# Altium schematic sheet Main Loom Schematic.SchDoc
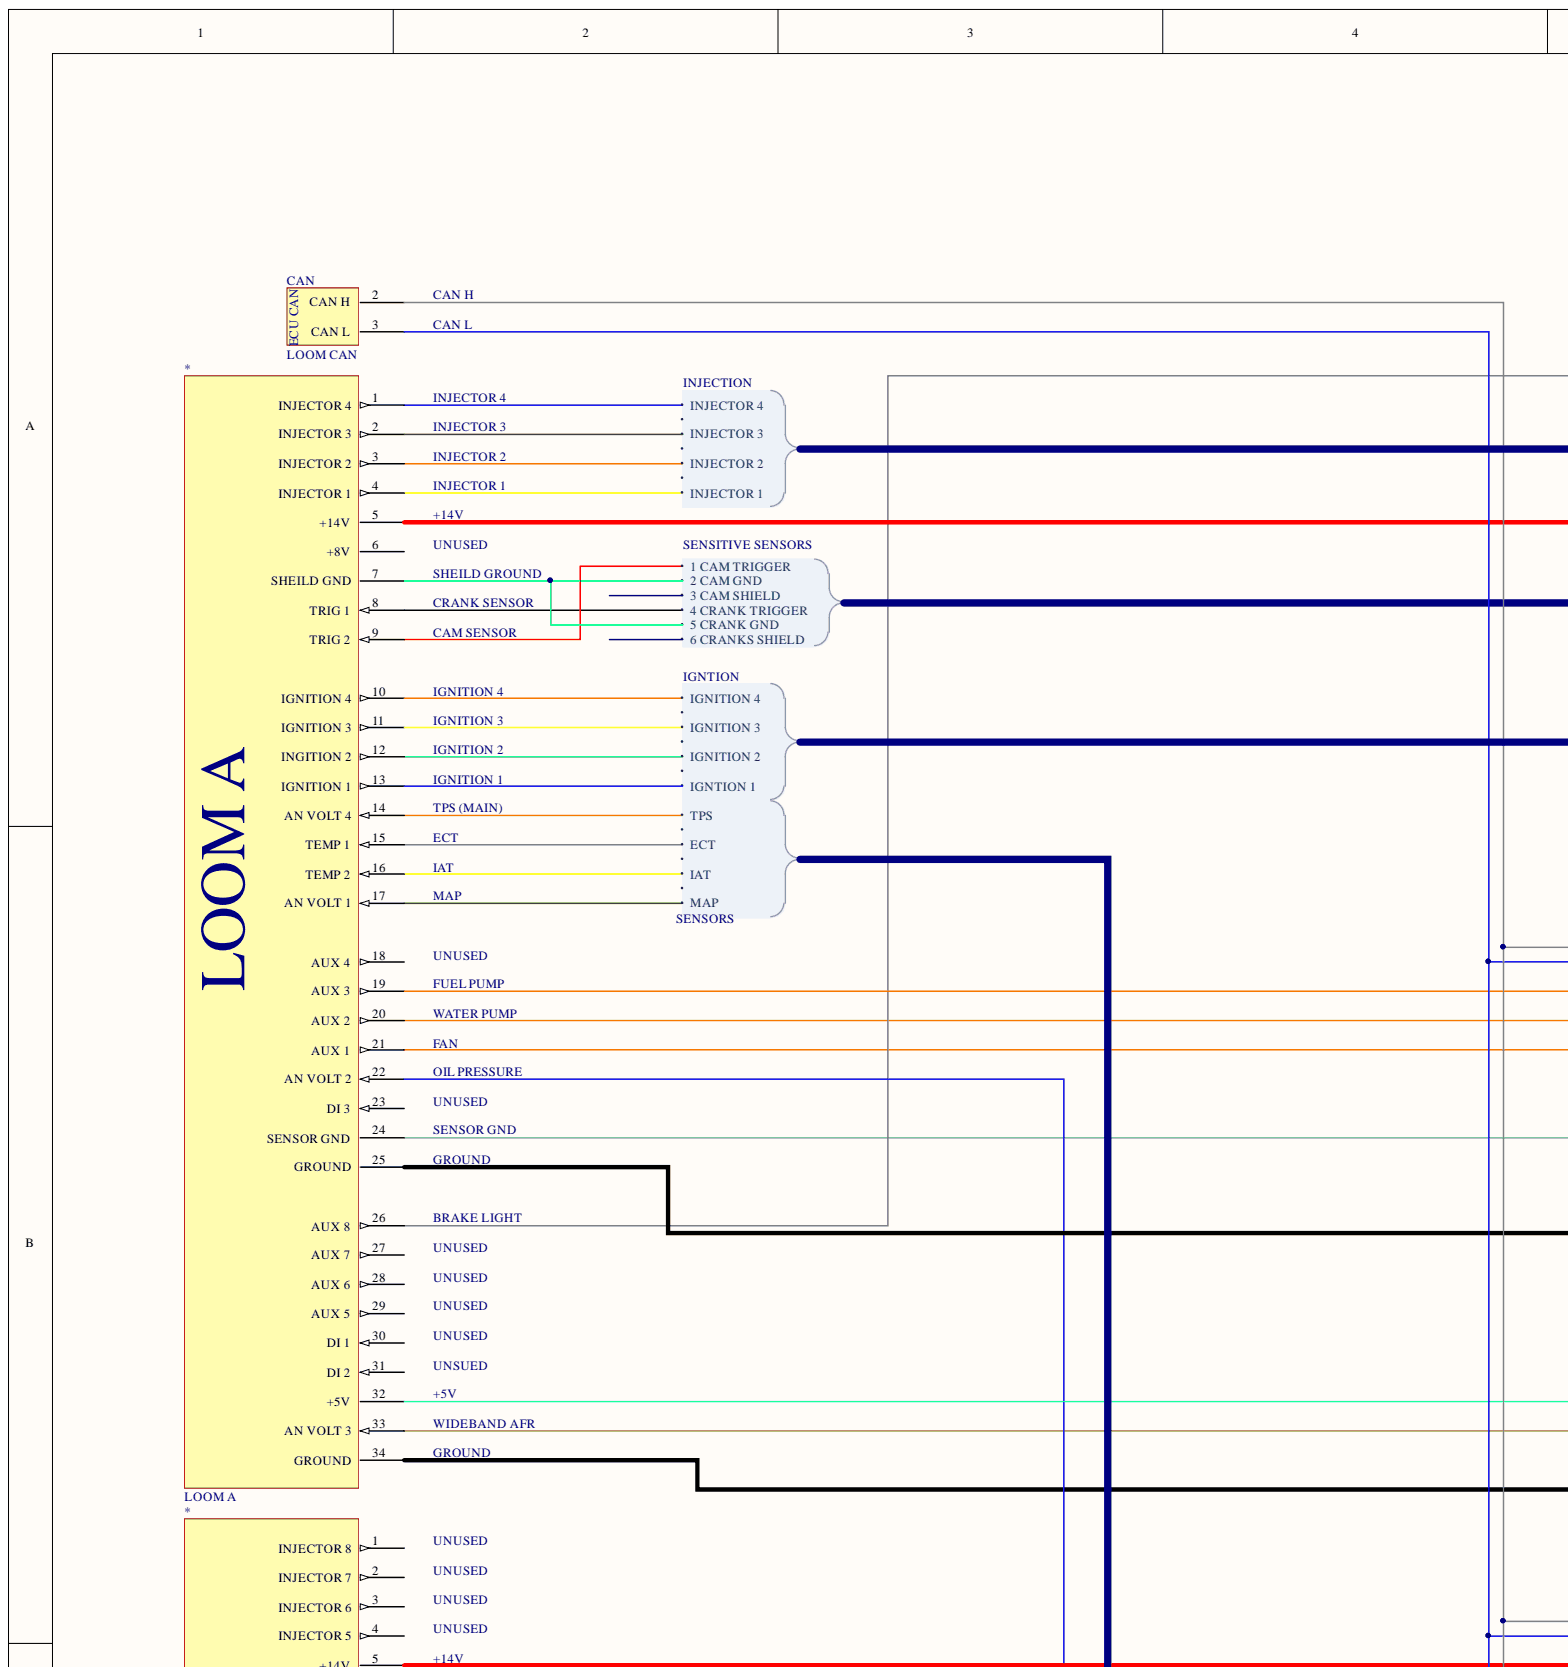
[diagram p0: Main Loom Schematic.SchDoc, top left]
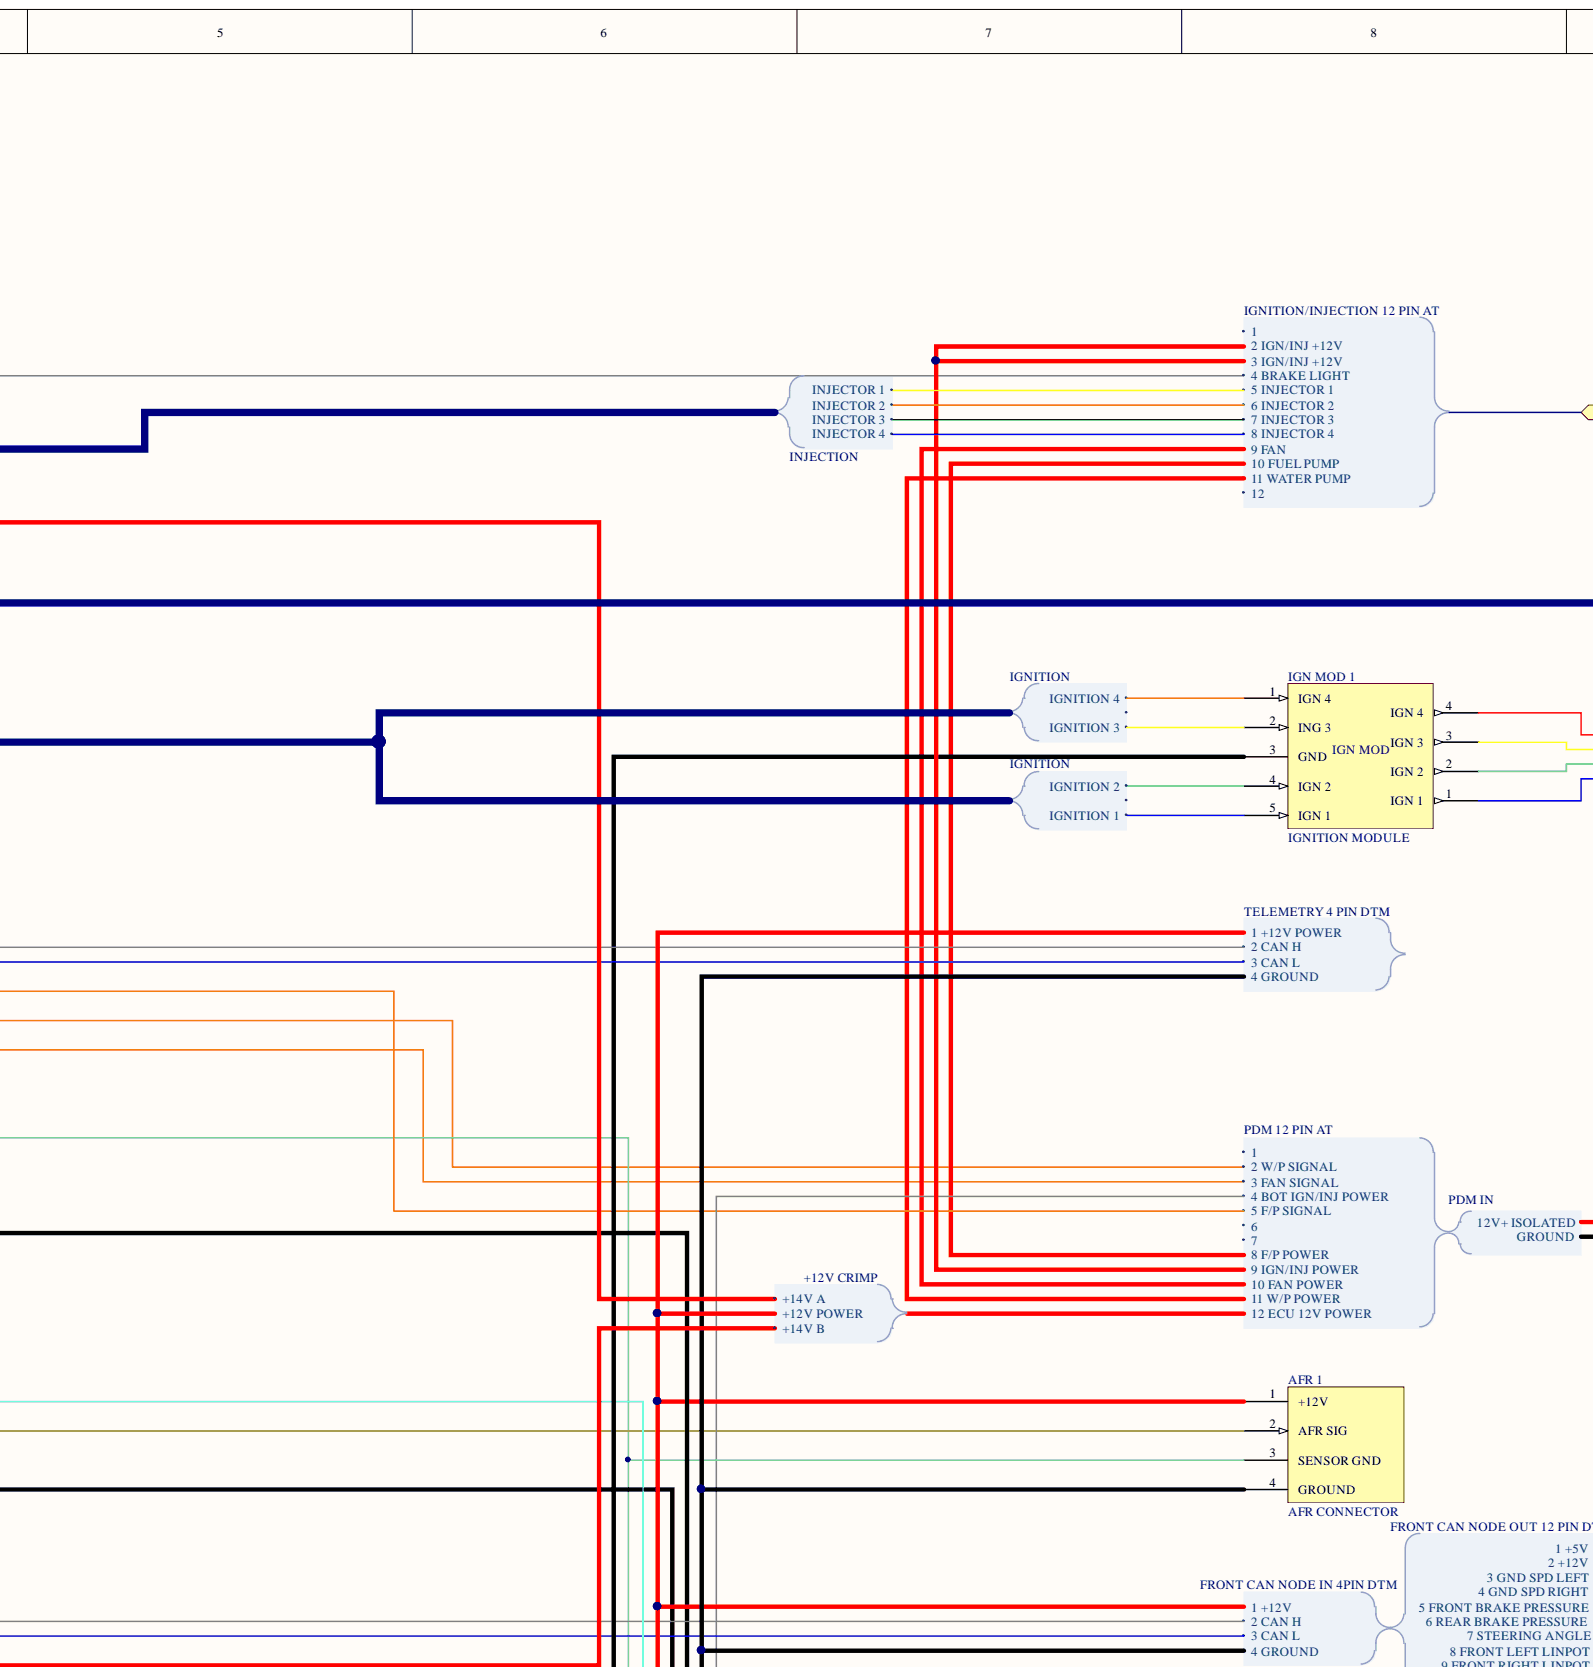
[diagram p1: Main Loom Schematic.SchDoc, top centre]
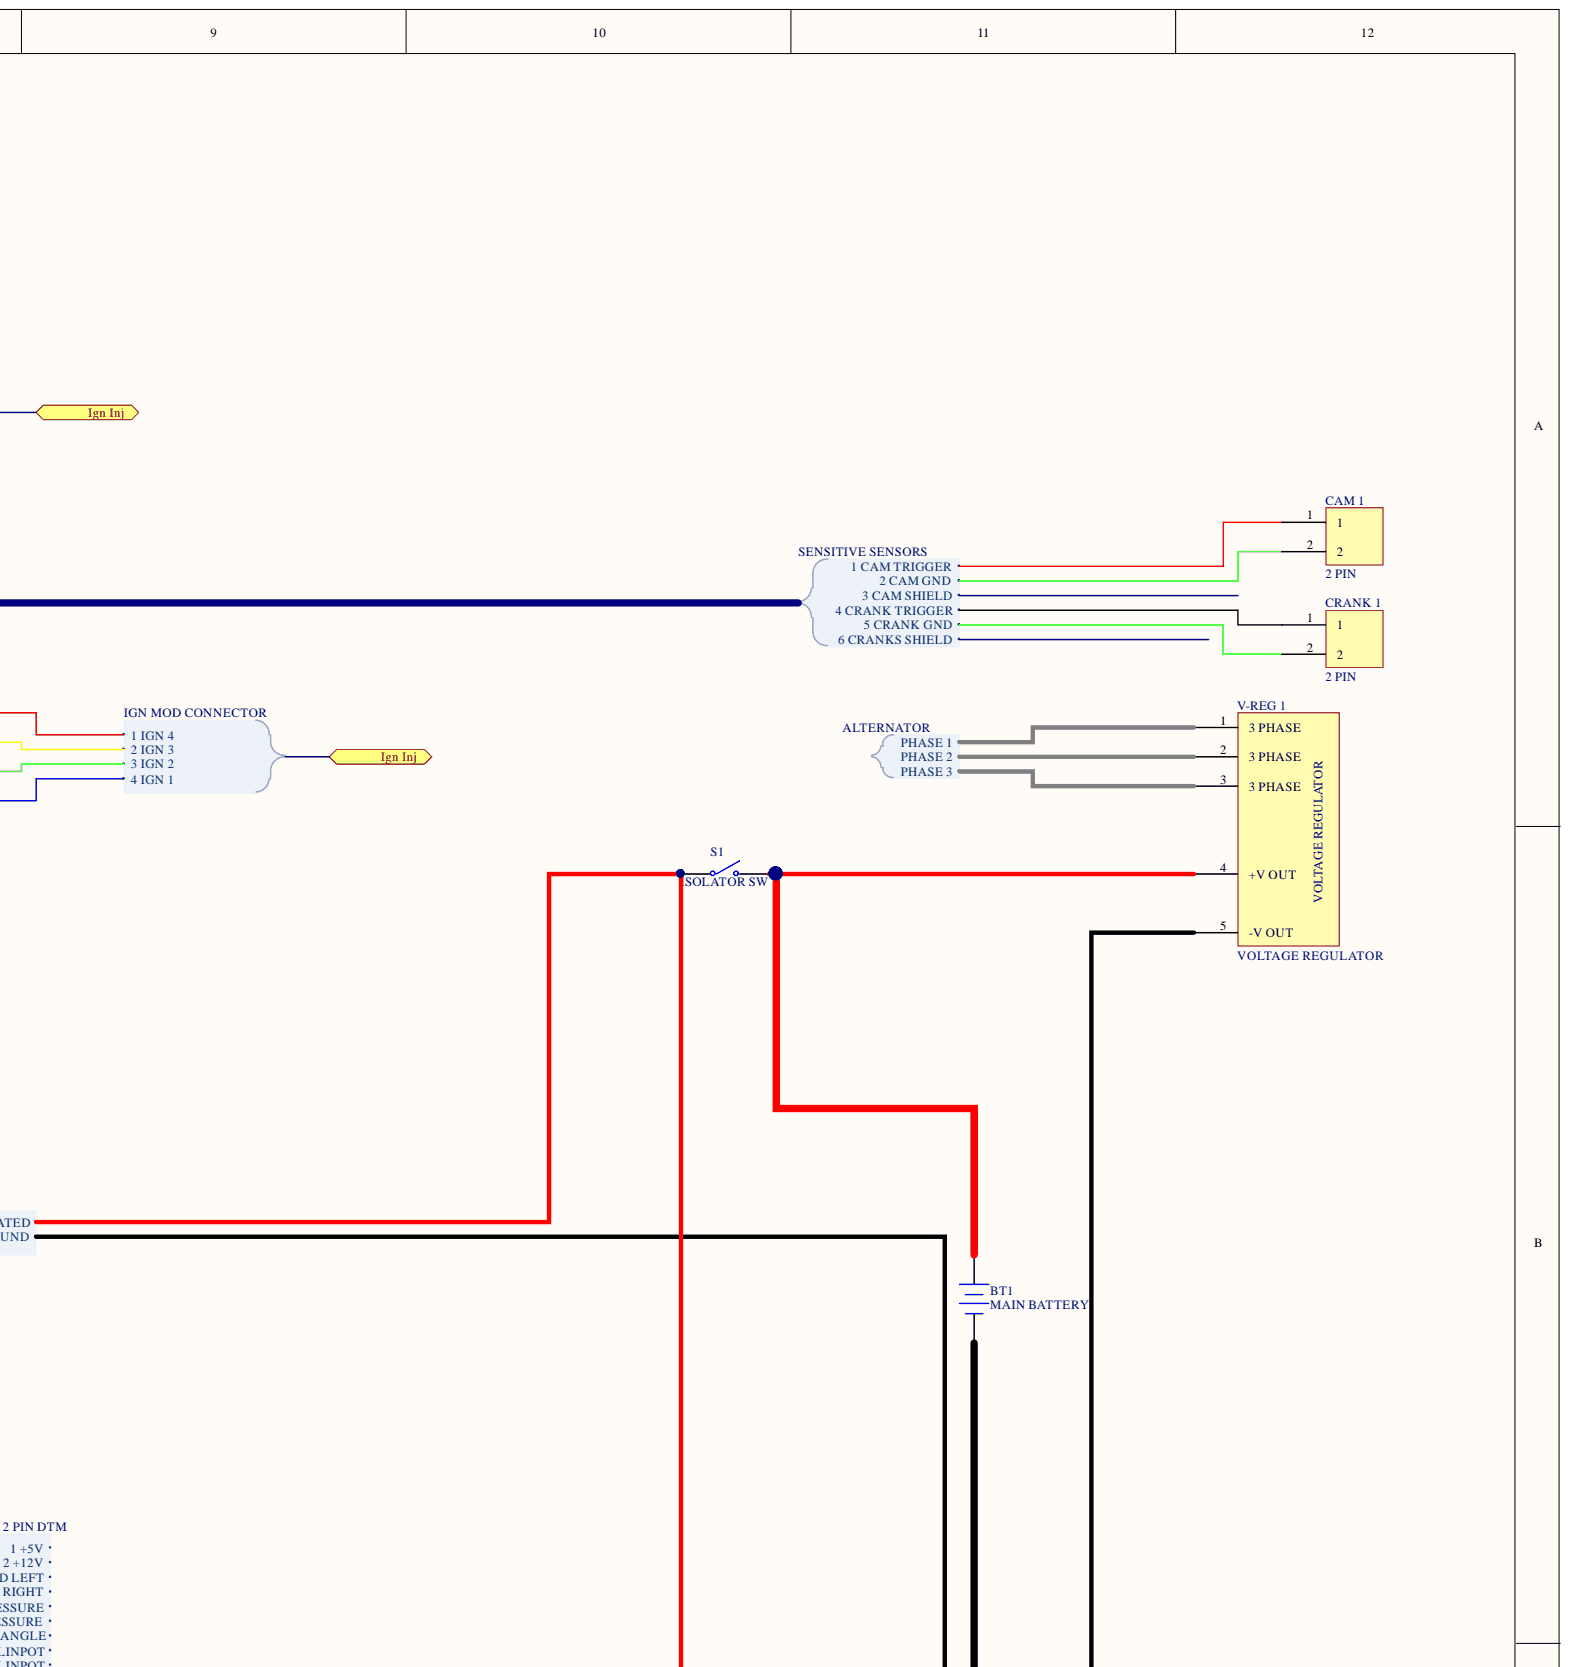
[diagram p2: Main Loom Schematic.SchDoc, top right]
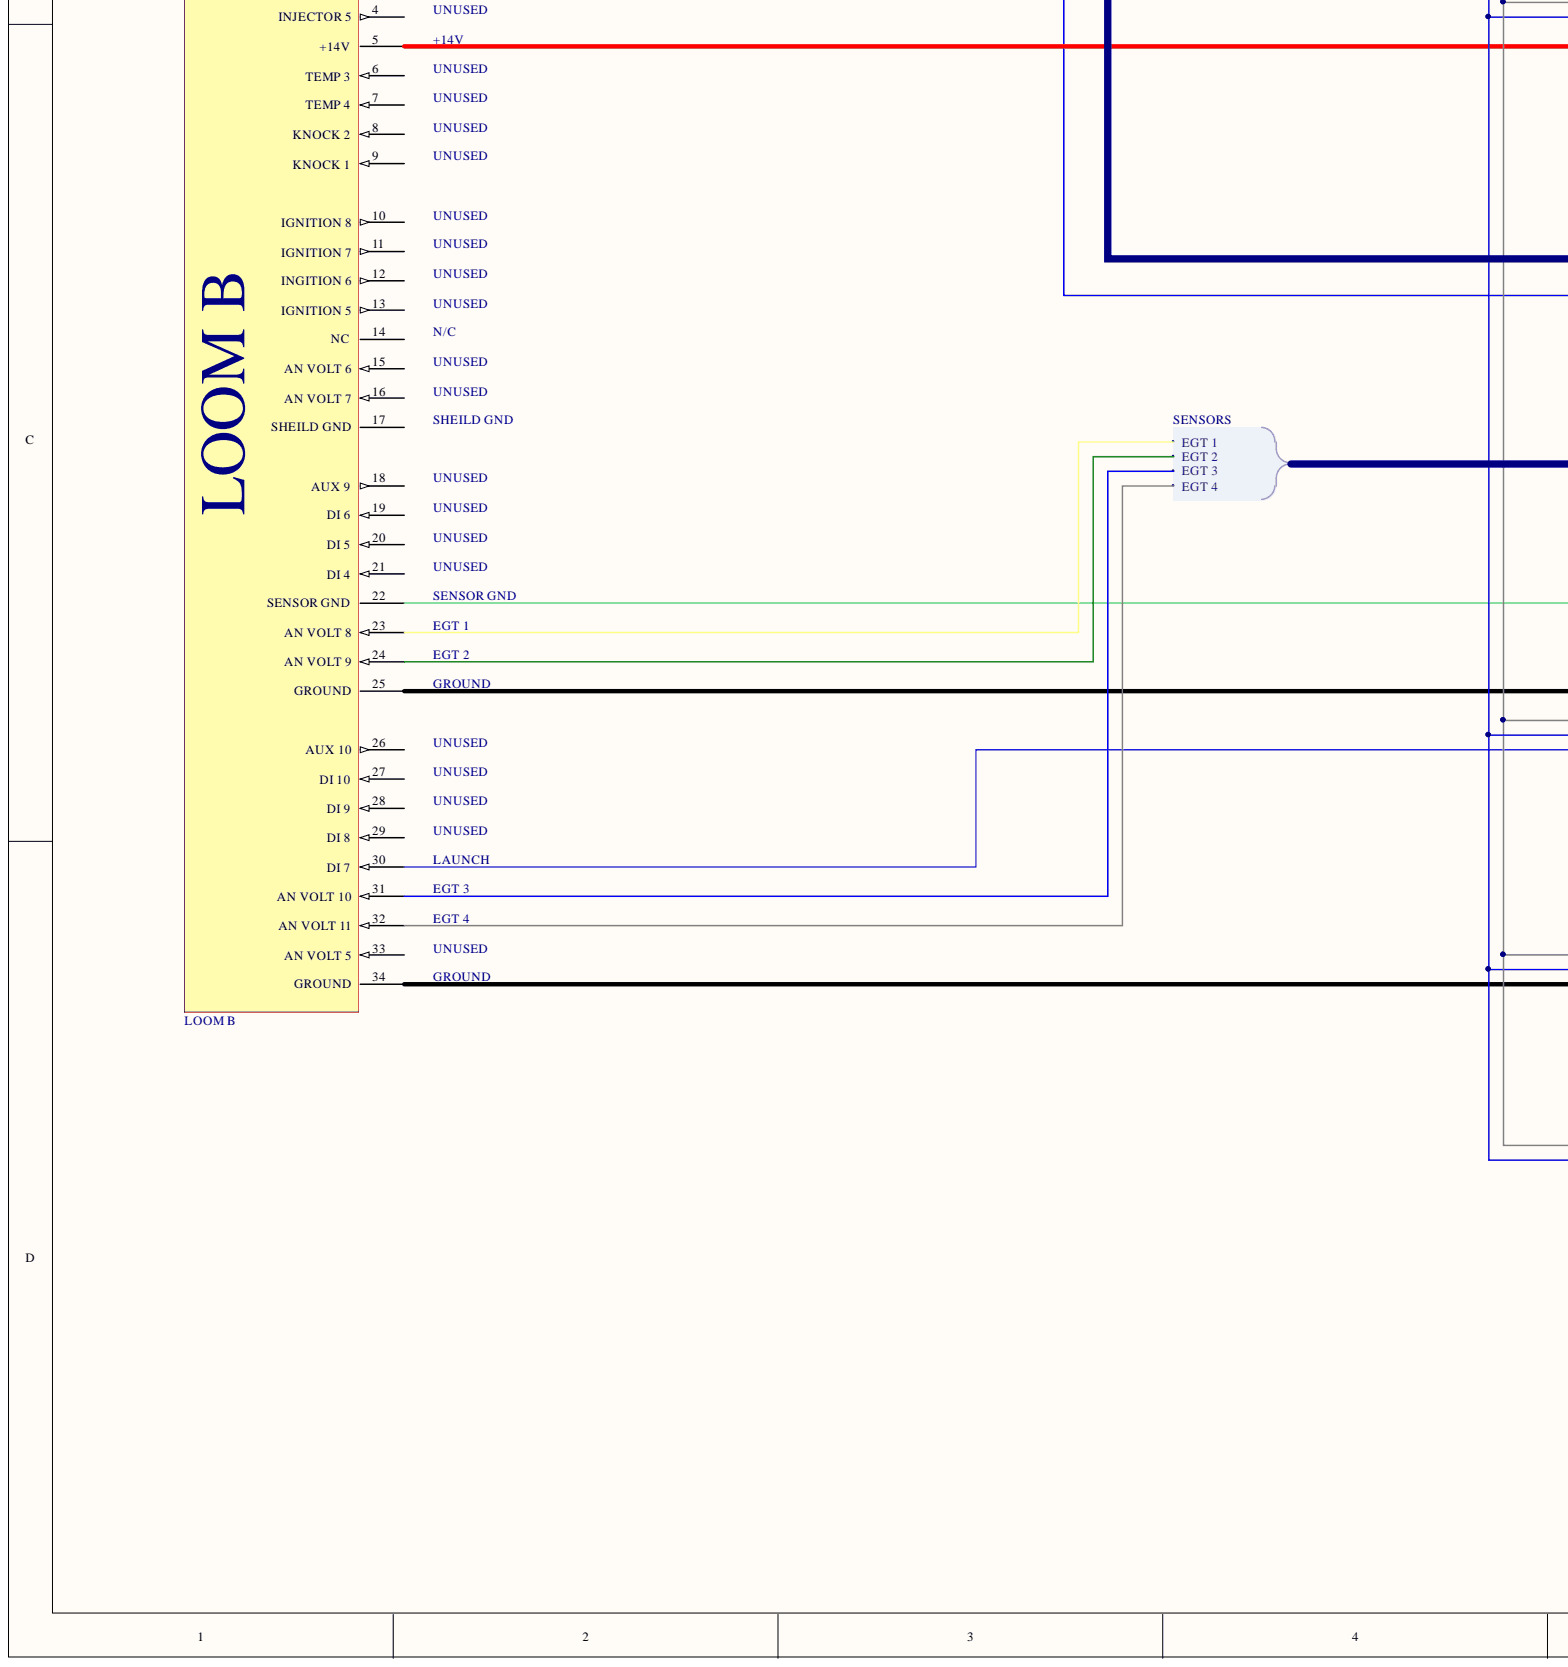
[diagram p3: Main Loom Schematic.SchDoc, bottom left]
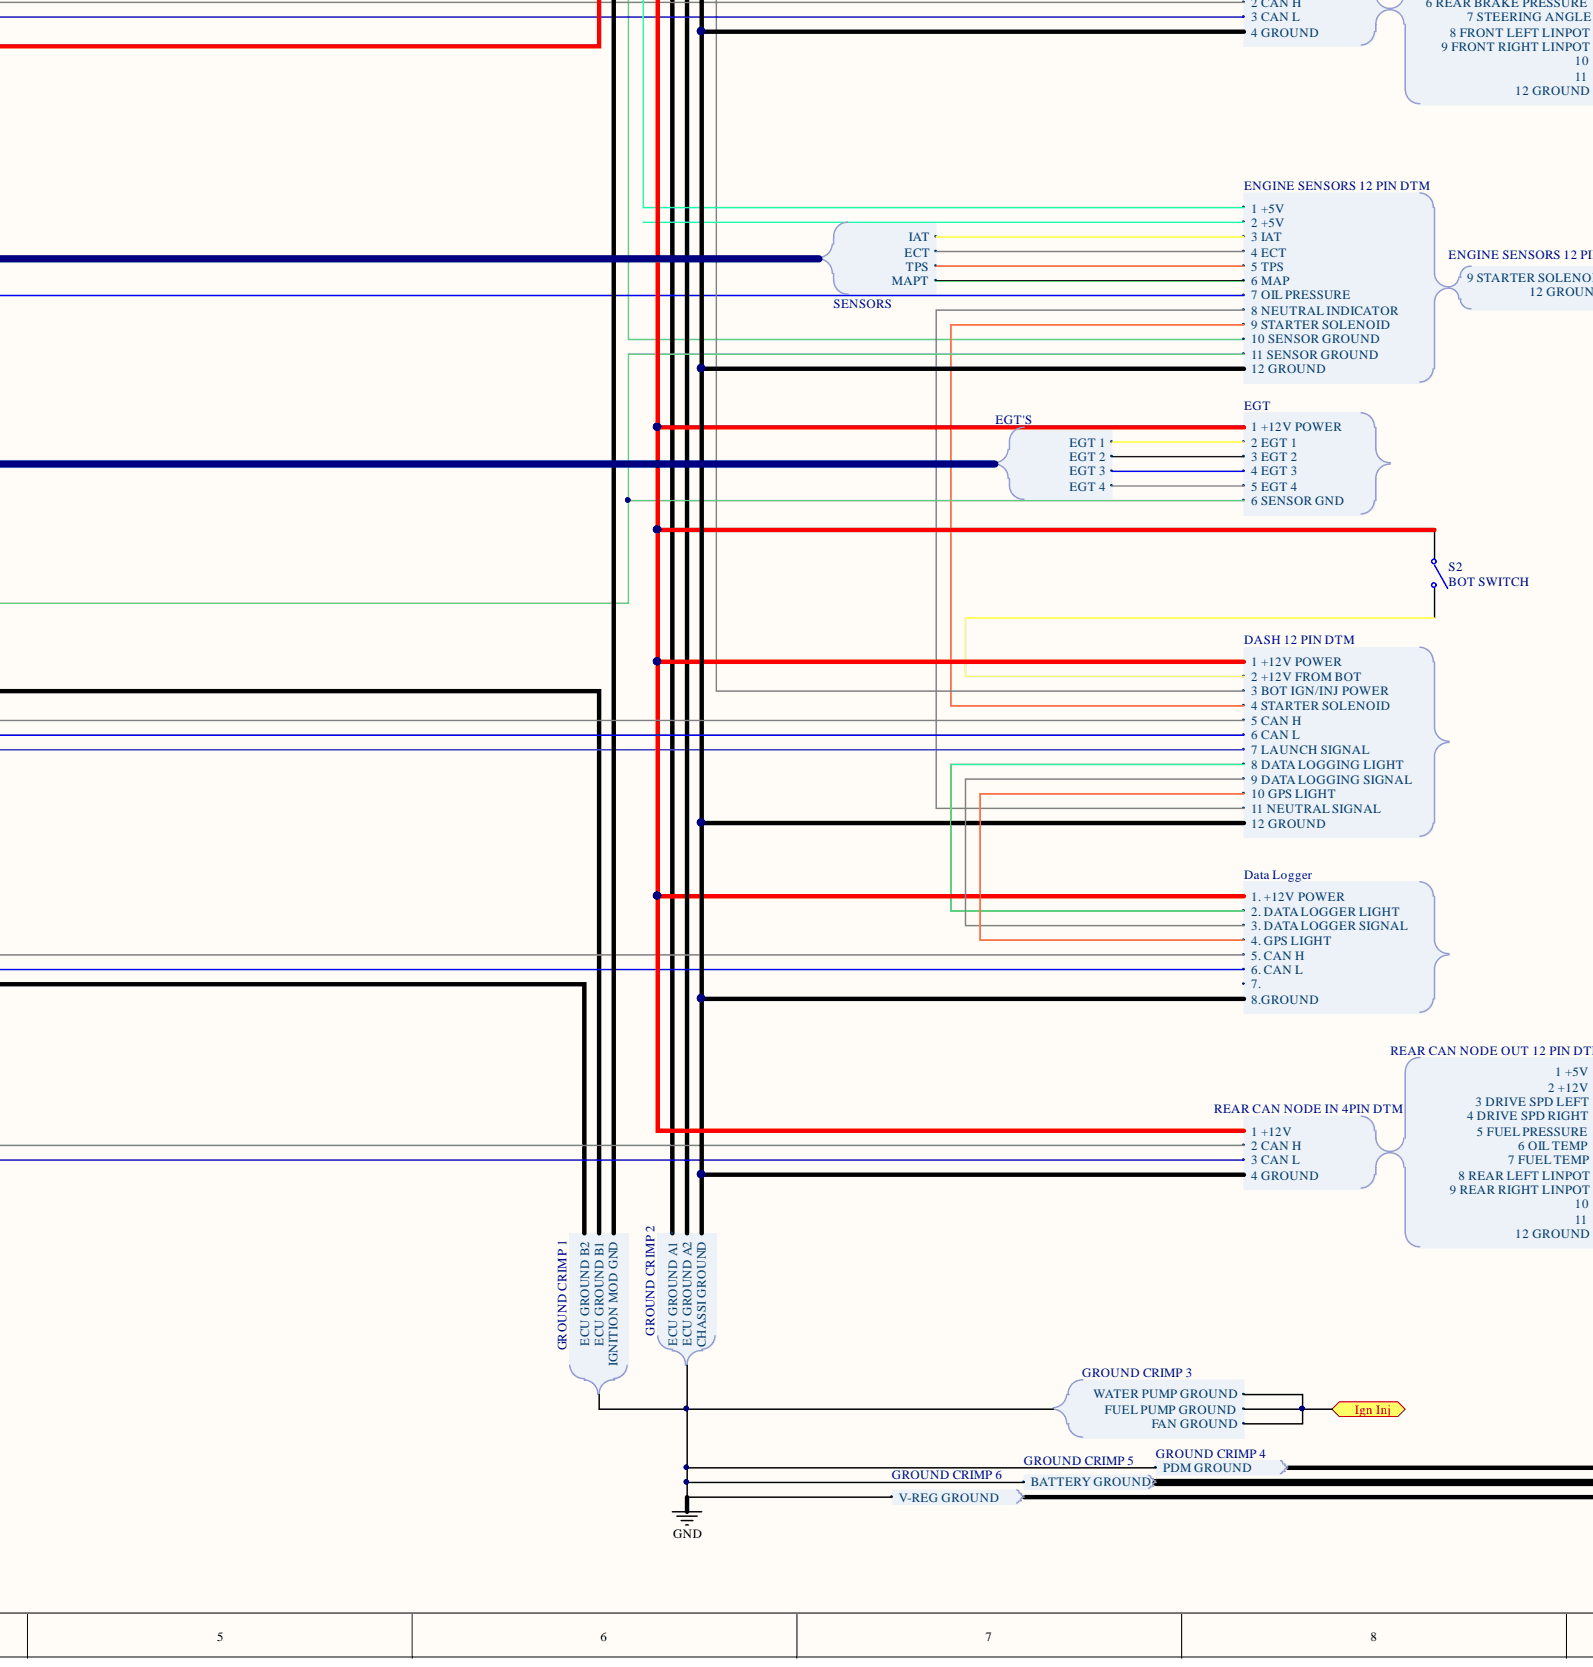
[diagram p4: Main Loom Schematic.SchDoc, bottom centre]
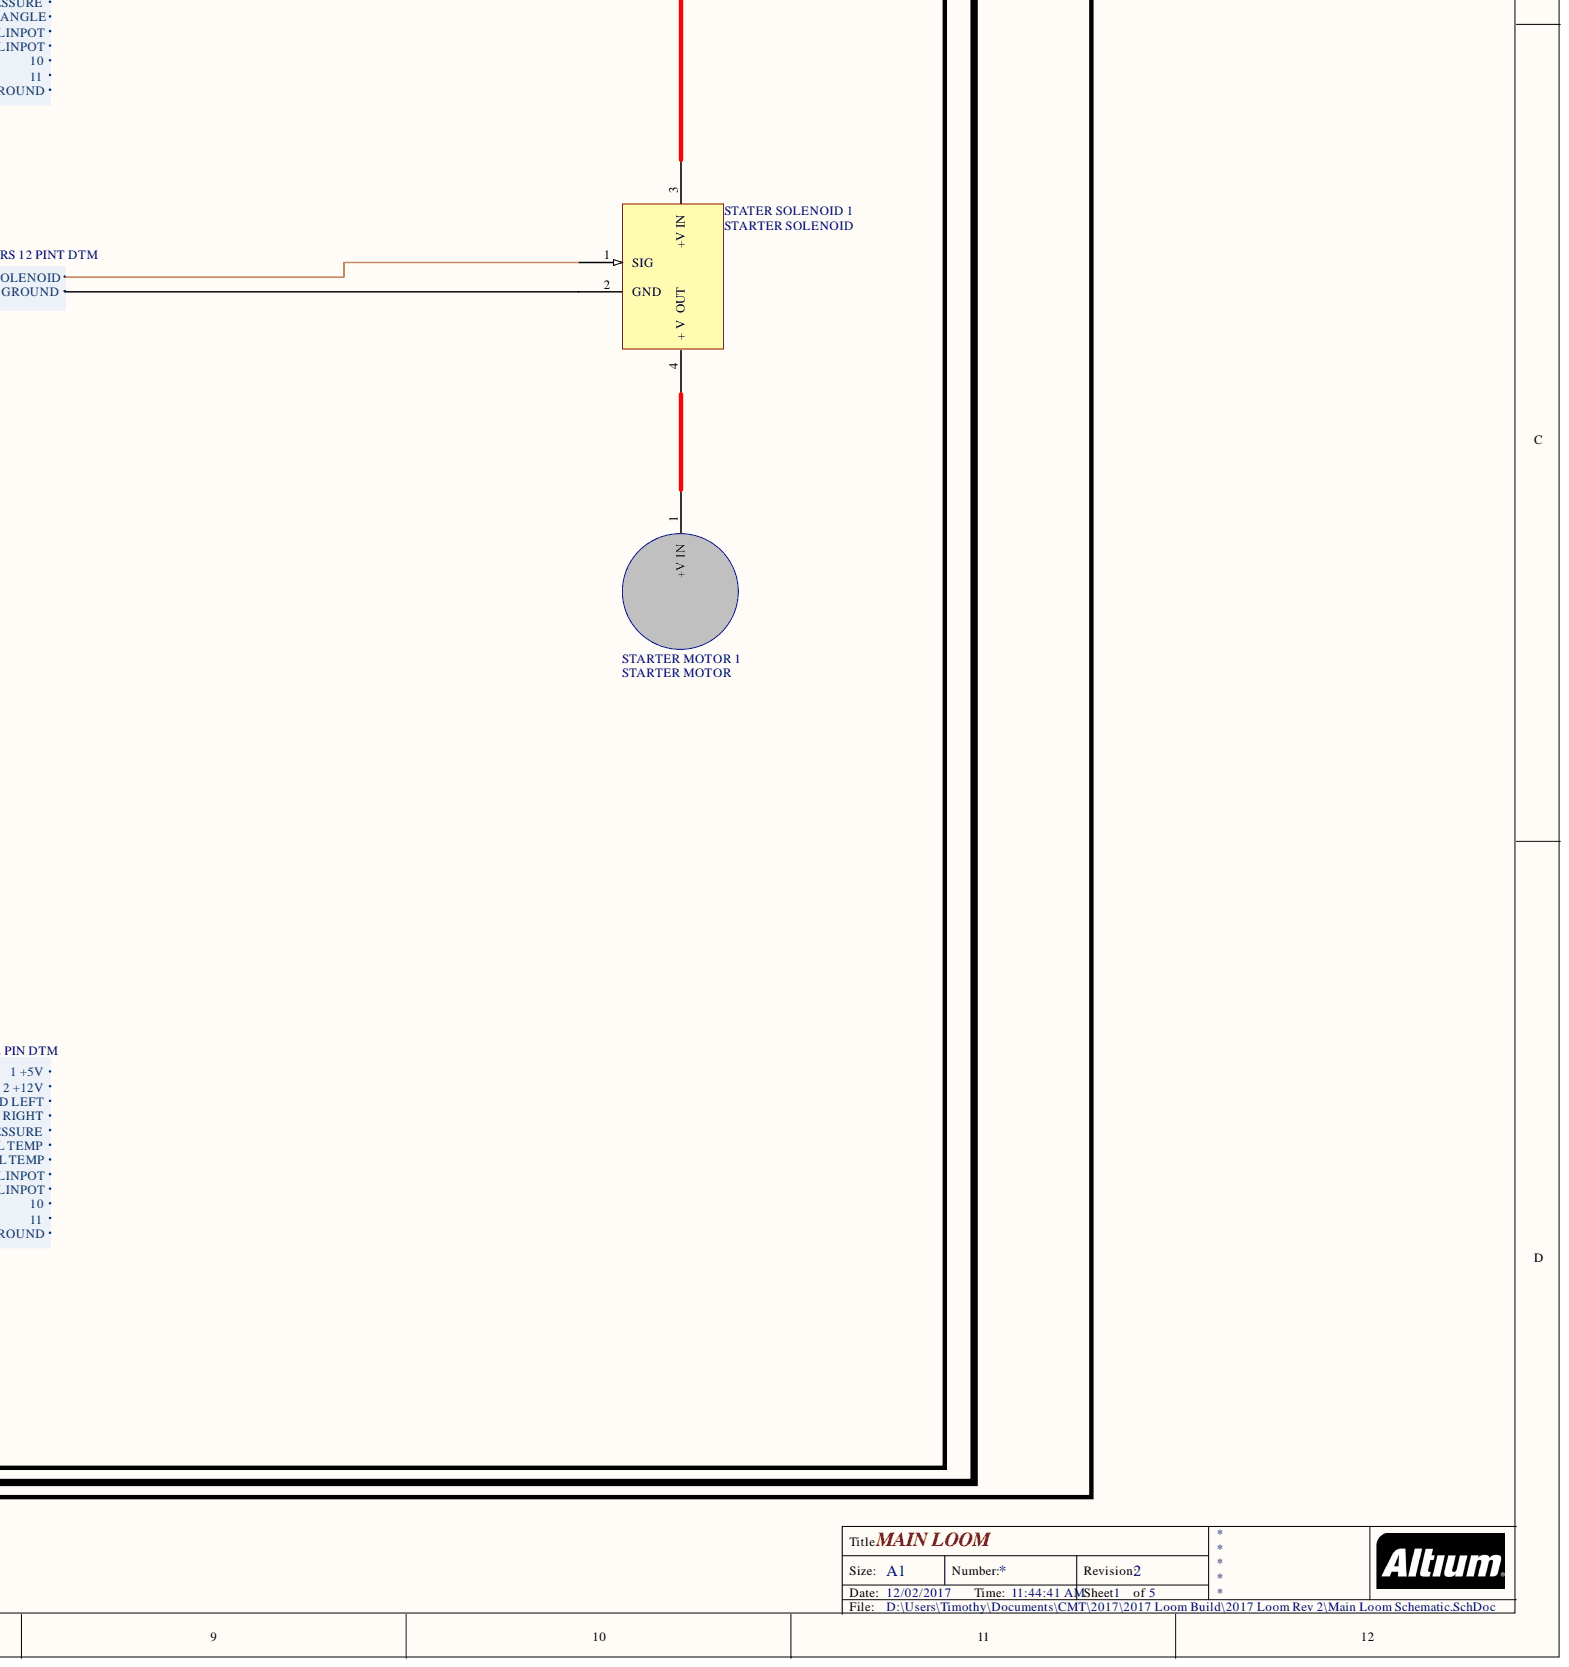
[diagram p5: Main Loom Schematic.SchDoc, bottom right]

## Parts
*  LOOM B
*  LOOM A
AFR_1  AFR CONNECTOR
BT1  MAIN BATTERY  [BAT-2]
CAM_1  2 PIN
CAN  LOOM CAN
CRANK_1  2 PIN
IGN_MOD_1  IGNITION MODULE
S1  ISOLATOR SW  [SPST-2]
S2  BOT SWITCH  [SPST-2]
STARTER_MOTOR_1  STARTER MOTOR
STATER_SOLENOID_1  STARTER SOLENOID
V-REG_1  VOLTAGE REGULATOR

## Ports
IGN INJ

## Nets
Net*_24: *.24 AFR_1.3(SENSOR_GND)
Net*_33: *.33 AFR_1.2
NetAFR 1_1: AFR_1.1(+12V) S2.1
NetBT1_1: BT1.1 S1.2 V-REG_1.4(+V_OUT)
NetS1_1: S1.1 STATER_SOLENOID_1.3(+V_IN)
NetSTARTER MOTOR 1_1: STARTER_MOTOR_1.1 STATER_SOLENOID_1.4(+_V)
GND1_BUS[..]:
N00021:
N00022:
N00023:
N00024:
N00025:
N00026:
N00027:
N00028: ; port IGN INJ
N00029:
N00030:
N00031:
N00032:
N00033:
N00034:
N00035:
N00036:
N00037:
N00038:
N00039:
N00040:
N00041:
N00042:
N00043:
N00044:
N00045:
N00046:
N00047:
N00048:
N00049:
N00050:
N00051:
N00052:
N00053:
N00054:
N00055:
N00056:

Not connected: * 1 2 3 4 5 6 7 8 9 10 11 12 13 14 15 16 17 18 19 20 21 22 23 25 26 27 28 29 30 31 32 34; AFR_1 4(GROUND); BT1 2; CAM_1 1 2; CAN 2 3; CRANK_1 1 2; IGN_MOD_1 1(IGN_1) 2(IGN_2) 3(GND) 4(IGN_2) 5(IGN_1); S2 2; STATER_SOLENOID_1 1(SIG) 2(GND); V-REG_1 1(3_PHASE) 2(3_PHASE) 3(3_PHASE) 5(-V_OUT)

## Library data of the parts
* = LOOM B: 34 pin ECU connector; Component Kind: Standard; Library Name: Loom Connectors.SchLib
* = LOOM A: 34 pin ECU connector; Component Kind: Standard; Library Name: Loom Connectors.SchLib
BT1 = Battery: Multicell Battery; Component Kind: Standard; LatestRevisionDate: 17-Jul-2002; LatestRevisionNote: Re-released for DXP Platform.; Library Name: Miscellaneous Devices.IntLib; PackageDescription: Battery; 2 Leads; PackageReference: BAT-2; Published: 24-Mar-1999; Publisher: Altium Limited
IGN_MOD_1 = IGNITION MODULE: BOSCH 4 PIN IGNITION MODULE 227 100 211; Component Kind: Standard; Library Name: Loom Components.SchLib
S1 S2 = SW-SPST: Single-Pole, Single-Throw Switch; Component Kind: Standard; LatestRevisionDate: 17-Jul-2002; LatestRevisionNote: Re-released for DXP Platform.; Library Name: Miscellaneous Devices.IntLib; PackageDescription: Switch; 2 Leads; PackageReference: SPST-2; Published: 24-Mar-1999; Publisher: Altium Limited
STARTER_MOTOR_1 = STARTER MOTOR: CBR600 STARTER MOTOR; Component Kind: Standard; Library Name: Loom Components.SchLib
V-REG_1 = VOLTAGE REGULATOR: SHINDENGEN F FH012AA; Component Kind: Standard; Library Name: Loom Components.SchLib
AFR_1 = AFR CONNECTOR: Component Kind: Standard; Library Name: Loom Connectors.SchLib
CAM_1 CRANK_1 = 2 PIN: Component Kind: Standard; Library Name: Loom Connectors.SchLib
CAN = LOOM CAN: Component Kind: Standard; Library Name: Loom Connectors.SchLib
STATER_SOLENOID_1 = STARTER SOLENOID: Component Kind: Standard; Library Name: Loom Components.SchLib
